# Altium schematic sheet [16] - POWER INPUT.SchDoc("[16] - POWER INPUT")
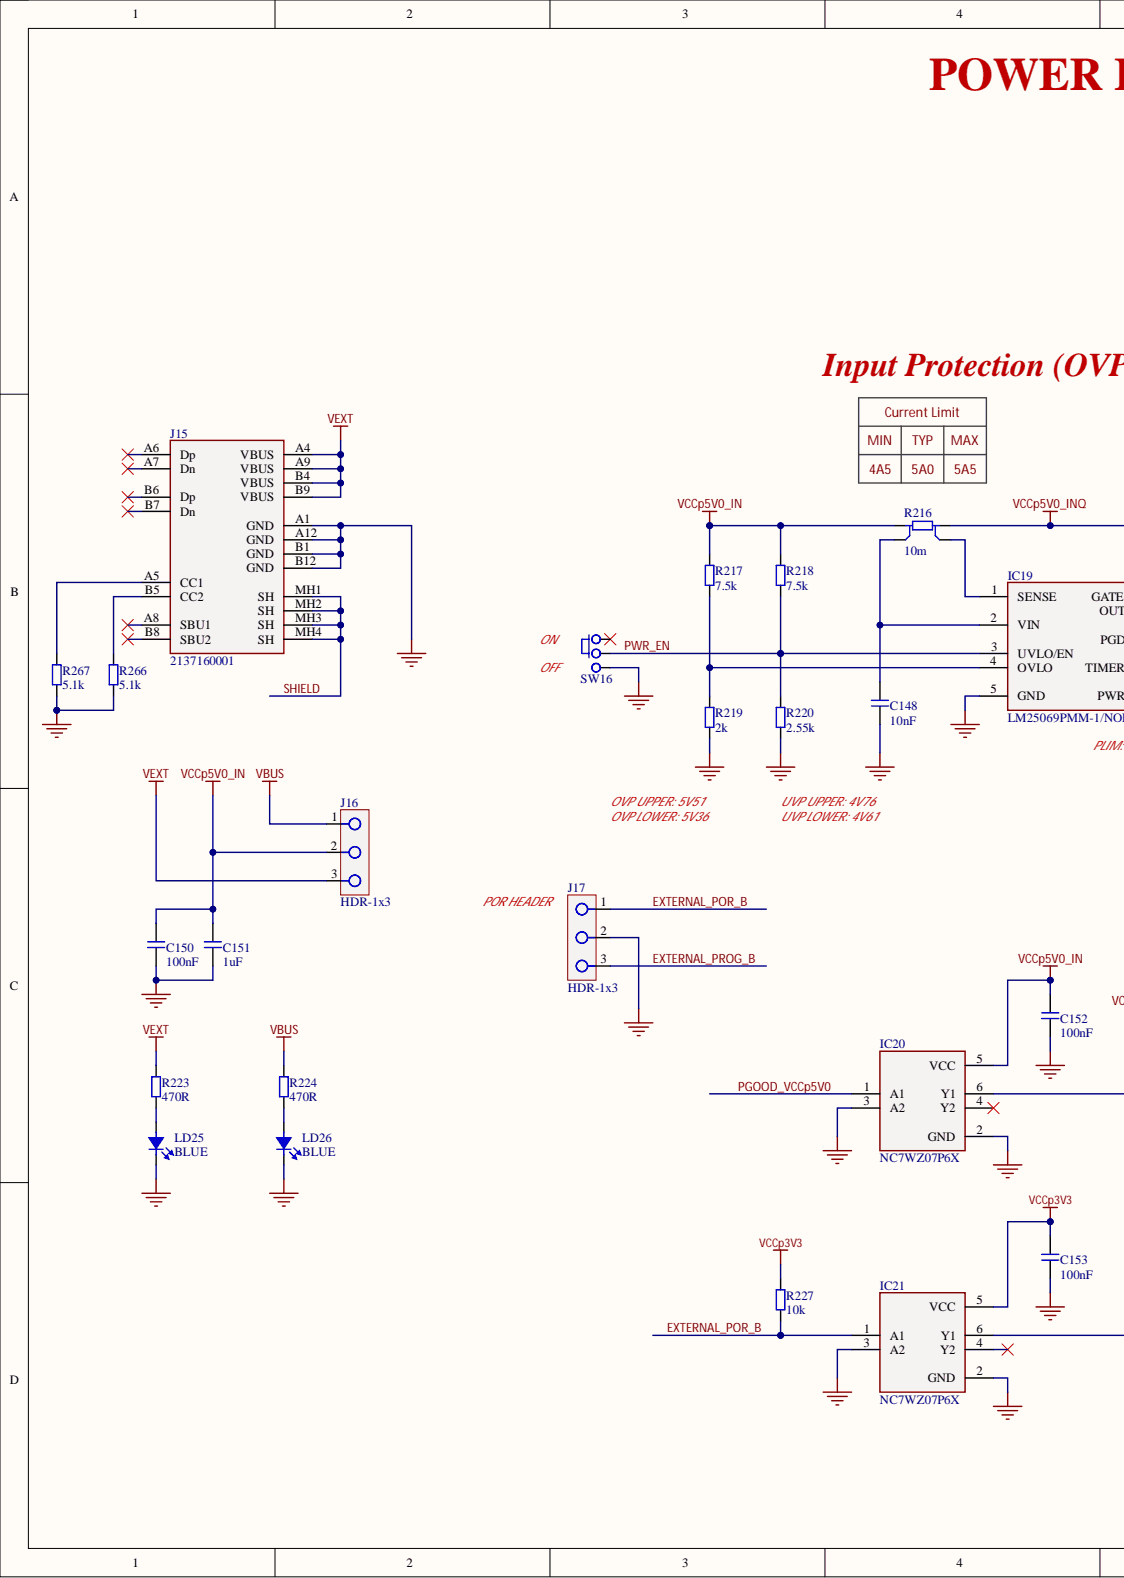
[diagram p0: [16] - POWER INPUT.SchDoc, left]
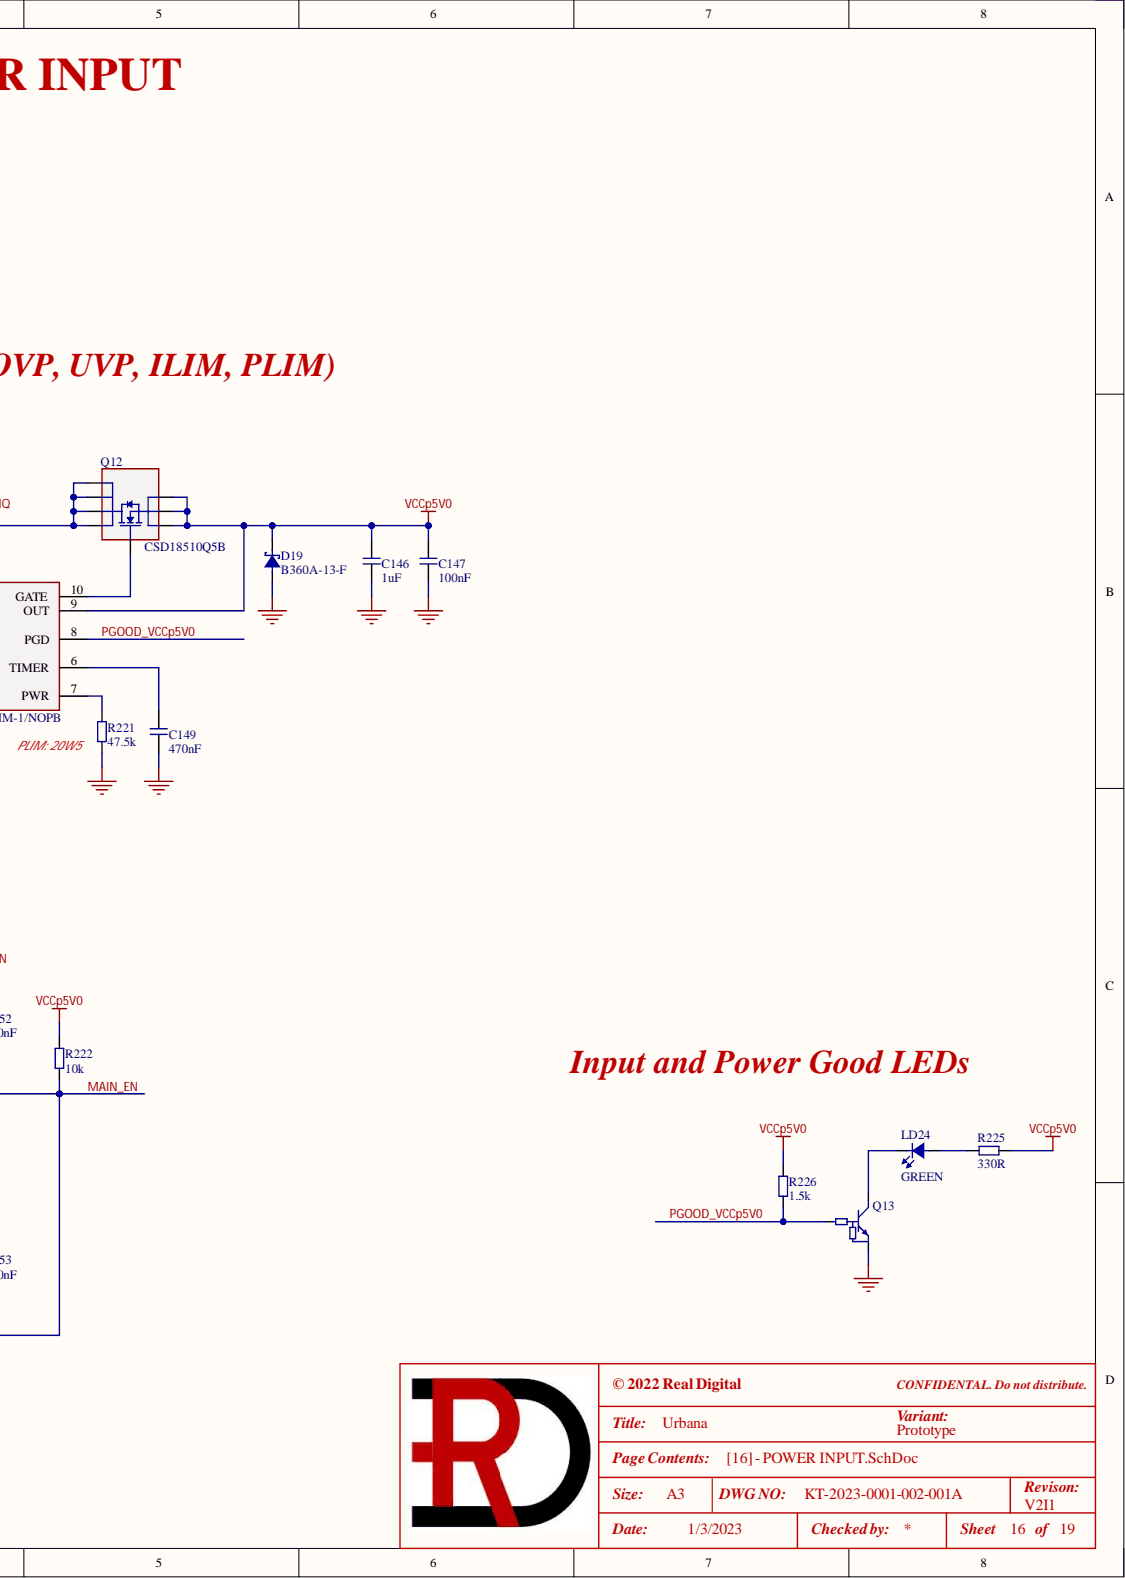
[diagram p1: [16] - POWER INPUT.SchDoc, right]

## Parts
C146  1uF  [C0603]
C147  100nF  [C0402]
C148  10nF  [C0402]
C149  470nF  [C0402]
C150  100nF  [C0402]
C151  1uF  [C0603]
C152  100nF  [C0402]
C153  100nF  [C0402]
D19  B360A-13-F  [DO-214AC_SMA - DI]
IC19  LM25069PMM-1/NOPB  [DGS0010A - TI]
IC20  NC7WZ07P6X  [DCK0006A_SC70-6 - TI]
IC21  NC7WZ07P6X  [DCK0006A_SC70-6 - TI]
J15  2137160001  [2137160001]
J16  HDR-1x3  [HDR1X3]
J17  HDR-1x3  [HDR1X3]
LD24  GREEN  [LD0603_Green]
LD25  BLUE  [LD0603_BLUE]
LD26  BLUE  [LD0603_BLUE]
Q12  CSD18510Q5B  [DNK0008A - TI]
Q13  DTC043ZEBTL  [SC89-EMT3F]  (value not drawn)
R216  10m  [KRL3216T4A]
R217  7.5k  [R0402]
R218  7.5k  [R0402]
R219  2k  [R0402]
R220  2.55k  [R0402]
R221  47.5k  [R0402]
R222  10k  [R0402]
R223  470R  [R0402]
R224  470R  [R0402]
R225  330R  [R0402]
R226  1.5k  [R0402]
R227  10k  [R0402]
R266  5.1k  [R0402]
R267  5.1k  [R0402]
SW16  Switch  [SW-SS12F20G4-10.5-HA-G]  (value not drawn)

## Nets
GND: C146.1 C147.1 C148.1 C149.1 C150.1 C151.1 C152.1 C153.1 D19.A IC19.5(GND) IC20.2(GND) IC20.3(A2) IC21.2(GND) IC21.3(A2) J15.A1(GND) J15.A12(GND) J15.B1(GND) J15.B12(GND) J17.2 LD25.K LD26.K Q13.GND R219.1 R220.1 R221.1 R266.1 R267.1 SW16.3
VBUS: J16.1 R224.2
VCCp3V3: C153.2 IC21.5(VCC) R227.2
VCCp5V0: C146.2 C147.2 D19.K IC19.9(OUT) Q12.1 Q12.2 Q12.3 R222.2 R225.2 R226.2
VCCp5V0_IN: C150.2 C151.2 C152.2 IC20.5(VCC) J16.2 R216.I1 R217.2 R218.2
VCCp5V0_INQ: Q12.5 Q12.6 Q12.7 Q12.8 R216.I2
VEXT: J15.A4(VBUS) J15.A9(VBUS) J15.B4(VBUS) J15.B9(VBUS) J16.3 R223.2
EXTERNAL_POR_B: IC21.1(A1) J17.1 R227.1
EXTERNAL_PROG_B: J17.3
MAIN_EN: IC20.6(Y1) IC21.6(Y1) R222.1
PGOOD_VCCp5V0: IC19.8(PGD) IC20.1(A1) Q13.IN R226.1
PWR_EN: IC19.3(UVLO/EN) R218.1 R220.2 SW16.2
SHIELD: J15.MH1(SH) J15.MH2(SH) J15.MH3(SH) J15.MH4(SH)
NetC148_2: C148.2 IC19.2(VIN) R216.E1
NetC149_2: C149.2 IC19.6(TIMER)
NetIC19_1: IC19.1(SENSE) R216.E2
NetIC19_4: IC19.4(OVLO) R217.1 R219.2
NetIC19_7: IC19.7(PWR) R221.2
NetIC19_10: IC19.10(GATE) Q12.4
NetJ15_A5: J15.A5(CC1) R267.2
NetJ15_B5: J15.B5(CC2) R266.2
NetLD24_A: LD24.A R225.1
NetLD24_K: LD24.K Q13.OUT
NetLD25_A: LD25.A R223.1
NetLD26_A: LD26.A R224.1

Not connected: IC20 4(Y2); IC21 4(Y2); J15 A6(Dp) A7(Dn) A8(SBU1) B6(Dp) B7(Dn) B8(SBU2); SW16 1

## Library data of the parts
C146 C151 = GCJ188R71E105KA01D: CAP CER 1uF 25V X7R 0603; Manufacturer 1: Murata Electronics; .Checked_PCB: AC; .Creator: RV; C P/N: 111-0602; LoadStatus: Load; Tolerance: 10%; Category: Capacitors; Component Kind: Standard; Library Name: KT-RealDigital.IntLib; Manufacturer 1 Part Number: GCJ188R71E105KA01D; Temperature Coefficient: X7R; Voltage - Rated: 25V; .Checked_SCH: AC
C147 C150 C152 C153 = GRM155R71E104KE14J: CAP CER 0.1uF 25V X7R 0402; Manufacturer 1: Murata Electronics; .Checked_PCB: AC; .Creator: RV; C P/N: RD-111-0006; LoadStatus: Load; Tolerance: 10%; Category: Capacitors; Component Kind: Standard; Library Name: KT-RealDigital.IntLib; Manufacturer 1 Part Number: GRM155R71E104KE14J; Temperature Coefficient: X7R; Voltage - Rated: 25V; .Checked_SCH: AC
C148 = GRM155R71H103KA88J: CAP CER 10000pF 50V X7R 0402; Manufacturer 1: Murata Electronics; .Checked_PCB: AC; .Creator: RV; C P/N: RD-111-0003; LoadStatus: Load; Tolerance: 10%; Category: Capacitors; Component Kind: Standard; Library Name: KT-RealDigital.IntLib; Manufacturer 1 Part Number: GRM155R71H103KA88J; Temperature Coefficient: X7R; Voltage - Rated: 50V; .Checked_SCH: AC
C149 = GRT155R61C474ME01D: CAP CER 0.47uF 16V X5R 0402; Manufacturer 1: Murata Electronics; Manufacturer 1 Part Number: GRM155R61H474KE11D; .Checked_PCB: RV; LoadStatus: Load; Tolerance: 20%; Category: Capacitors; Component Kind: Standard; Library Name: KT-RealDigital.IntLib; Temperature Coefficient: X5R; Voltage - Rated: 16V; .Checked_SCH: RV; .Creator: RV
D19 = B360A-13-F: DIODE SCHOTTKY 60V 3A SMA; Manufacturer 1: Diodes Incorporated; .Checked_PCB: RV; LoadStatus: Load; Category: Diodes; Component Kind: Standard; Library Name: KT-RealDigital.IntLib; Manufacturer 1 Part Number: B360A-13-F; .Checked_SCH: RV; .Creator: RV
IC19 = LM25069PMM-1/NOPB: IC HOT SWAP CTRLR GP 10VSSOP; Manufacturer 1: Texas Instruments; .Checked_PCB: RV; LoadStatus: Load; Category: IC; Component Kind: Standard; Library Name: KT-RealDigital.IntLib; Manufacturer 1 Part Number: LM25069PMM-1/NOPB; .Checked_SCH: RV; .Creator: RV
IC20 IC21 = NC7WZ07P6X: IC BUF NON-INVERT 5.5V SC70-6; Manufacturer 1: ON Semiconductor; .Checked_PCB: RV; LoadStatus: Load; Category: IC; Component Kind: Standard; Library Name: KT-RealDigital.IntLib; Manufacturer 1 Part Number: NC7WZ07P6X; .Checked_SCH: RV; .Creator: RV
J15 = 2137160001: CONN RCPT USB2.0 TYPE C 24POS RA; Manufacturer 1: Molex; .Checked_PCB: RV; LoadStatus: Load; Category: Connectors; Component Kind: Standard; Library Name: KT-RealDigital.IntLib; Manufacturer 1 Part Number: 2137160001; .Checked_SCH: RV; .Creator: RV
J16 J17 = HDR-1x3: Header 2.54mm, 1x3; Manufacturer 1: Centronic Precision Electronic; Manufacturer 1 Part Number: H6-80-03; .Checked_PCB: AC; .Creator: RV; C P/N: 163-0035; LoadStatus: Load; Category: Header; Component Kind: Standard; Library Name: KT-RealDigital.IntLib; .Checked_SCH: AC
LD24 = LED_GREEN: LED GREEN 0603; Manufacturer 1: Everlight Electronics CO., LTD; Manufacturer 1 Part Number: 19-21SYGC/S530-E4/TR8; .Checked_PCB: AC; .Creator: RV; C P/N: 121-0006; LoadStatus: Load; Category: Led; Component Kind: Standard; Library Name: KT-RealDigital.IntLib; .Checked_SCH: AC
LD25 LD26 = LED_BLUE: LED BLUE 0603; Manufacturer 1: Victory Electronics; Manufacturer 1 Part Number: VS 2288M; .Checked_PCB: RV; C P/N: 121-0444; LoadStatus: Load; Category: Led; Component Kind: Standard; Library Name: KT-RealDigital.IntLib; .Checked_SCH: RV; .Creator: RV
Q12 = CSD18510Q5B: MOSFET N-CH 40V 300A 8VSON; Manufacturer 1: Texas Instruments; .Checked_PCB: RV; LoadStatus: Load; Category: MOSFETs; Component Kind: Standard; Library Name: KT-RealDigital.IntLib; Manufacturer 1 Part Number: CSD18510Q5B; .Checked_SCH: RV; .Creator: RV
Q13 = DTC043ZEBTL: TRANS PREBIAS NPN 50V EMT3F; Manufacturer 1: Rohm Semiconductor; .Checked_PCB: RV; LoadStatus: Load; Category: BJTs; Component Kind: Standard; Library Name: KT-RealDigital.IntLib; Manufacturer 1 Part Number: DTC043ZEBTL; .Checked_SCH: RV; .Creator: RV
R216 = KRL3216T4A-M-R010-F-T1: RES 0.01 OHM 1W 1206 WIDE; Manufacturer 1: Susumu; .Checked_PCB: RV; LoadStatus: Load; Tolerance: 1%; Category: Resistors; Component Kind: Standard; Library Name: KT-RealDigital.IntLib; Manufacturer 1 Part Number: KRL3216T4A-M-R010-F-T1; .Checked_SCH: RV; .Creator: RV
R217 R218 = RC0402FR-077K5P: RES SMD 7.5K OHM 1% 1/16W 0402; Manufacturer 1: YAGEO; .Checked_PCB: RV; LoadStatus: Load; Category: Resistors; Component Kind: Standard; Library Name: KT-RealDigital.IntLib; Manufacturer 1 Part Number: RC0402FR-077K5P; Tolerance: 1%; .Checked_SCH: RV; .Creator: RV
R219 = RC0402FR-072KP: RES SMD 2K OHM 1% 1/16W 0402; Manufacturer 1: YAGEO; .Checked_PCB: RV; LoadStatus: Load; Category: Resistors; Component Kind: Standard; Library Name: KT-RealDigital.IntLib; Manufacturer 1 Part Number: RC0402FR-072KP; Tolerance: 1%; .Checked_SCH: RV; .Creator: RV
R220 = RC0402FR-072K55L: RES SMD 2.55K OHM 1% 1/16W 0402; Manufacturer 1: Yageo; .Checked_PCB: RV; LoadStatus: Load; Category: Resistors; Component Kind: Standard; Library Name: KT-RealDigital.IntLib; Manufacturer 1 Part Number: RC0402FR-072K55L; Tolerance: 1%; .Checked_SCH: RV; .Creator: RV
R221 = RC0402FR-0747K5L: RES SMD 47.5K OHM 1% 1/16W 0402; Manufacturer 1: Yageo; .Checked_PCB: RV; LoadStatus: Load; Category: Resistors; Component Kind: Standard; Library Name: KT-RealDigital.IntLib; Manufacturer 1 Part Number: RC0402FR-0747K5L; Tolerance: 1%; .Checked_SCH: RV; .Creator: RV
R222 R227 = RC0402FR-0710KL: RES SMD 10K OHM 1% 1/16W 0402; Manufacturer 1: Yageo; .Checked_PCB: AC; .Creator: RV; LoadStatus: Load; Category: Resistors; Component Kind: Standard; Library Name: KT-RealDigital.IntLib; Manufacturer 1 Part Number: RC0402FR-0710KL; Tolerance: 1%; .Checked_SCH: AC
R223 R224 = RC0402JR-07470RL: RES SMD 470 OHM 5% 1/16W 0402; Manufacturer 1: Yageo; .Checked_PCB: AC; .Creator: RV; C P/N: 101-0052; LoadStatus: Load; Category: Resistors; Component Kind: Standard; Library Name: KT-RealDigital.IntLib; Manufacturer 1 Part Number: RC0402JR-07470RL; Tolerance: 5%; .Checked_SCH: AC
R225 = RC0402JR-07330RL: RES SMD 330 OHM 5% 1/16W 0402; Manufacturer 1: Yageo; .Checked_PCB: AC; .Creator: RV; C P/N: 101-0112; LoadStatus: Load; Category: Resistors; Component Kind: Standard; Library Name: KT-RealDigital.IntLib; Manufacturer 1 Part Number: RC0402JR-07330RL; Tolerance: 5%; .Checked_SCH: AC
R226 = RC0402FR-071K5L: RES 1.5K OHM 1% 1/16W 0402; Manufacturer 1 Part Number: YAGEO; .Checked_PCB: RV; LoadStatus: Load; Category: Resistors; Component Kind: Standard; Library Name: KT-RealDigital.IntLib; Manufacturer 1: RC0402FR-071K5L; Tolerance: 1%; .Checked_SCH: RV; .Creator: RV
R266 R267 = RC0402FR-135K1L: RES 5.1K OHM 1% 1/16W 0402; Manufacturer 1: YAGEO; .Checked_PCB: RV; LoadStatus: Load; Category: Resistors; Component Kind: Standard; Library Name: KT-RealDigital.IntLib; Manufacturer 1 Part Number: RC0402FR-135K1L; Tolerance: 1%; .Checked_SCH: RV; .Creator: RV
SW16 = Slide_Switch_Long_Leads: Slide Switch Long Leads (7.35mm), SPDT, Thru Hole,; Manufacturer 1: SWITRONIC INDUSTRIAL CORP.; Manufacturer 1 Part Number: SS12F20G4-10.5-HA-G; .Checked_PCB: AC; .Creator: RV; C P/N: 153-0001; LoadStatus: Load; Category: Switches; Component Kind: Standard; Library Name: KT-RealDigital.IntLib; .Checked_SCH: AC
